# Altium schematic sheet Control.SchDoc(Control)
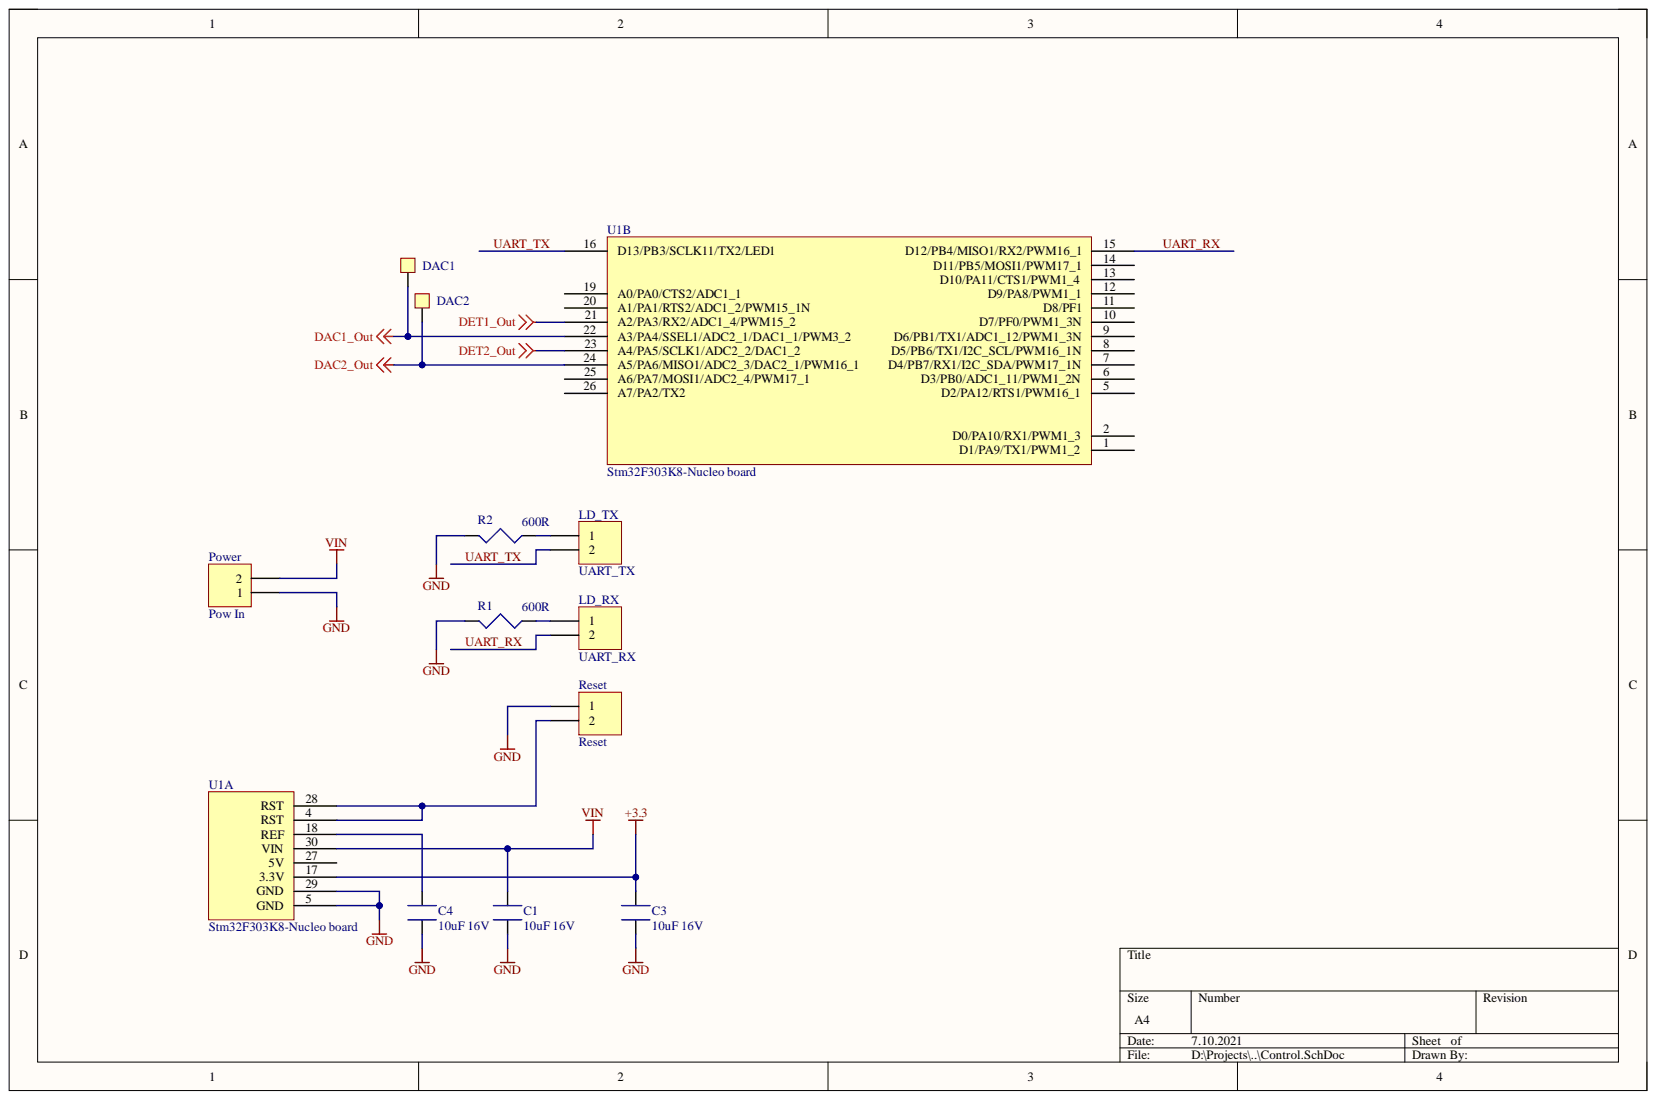
[diagram p0: Control.SchDoc]

## Parts
C1  10uF 16V  [0805_C]
C3  10uF 16V  [0805_C]
C4  10uF 16V  [0805_C]
DAC1  Point  [Test_Point]  (value not drawn)
DAC2  Point  [Test_Point]  (value not drawn)
LD_RX  UART_RX  [HDR1X2]
LD_TX  UART_TX  [HDR1X2]
Power  Pow In  [HDR1X2]
R1  600R  [0805_R]
R2  600R  [0805_R]
Reset  Reset  [HDR1X2]
U1  Stm32F303K8-Nucleo board  [F303-Nucleo]  (parts A,B)

## Nets
+3.3: C3.1 U1.17(3.3V)
DAC1_Out: DAC1.1 U1.22(A3/PA4/SSEL1/ADC2_1/DAC1_1/PWM3_2)
DAC2_Out: DAC2.1 U1.24(A5/PA6/MISO1/ADC2_3/DAC2_1/PWM16_1)
DET1_Out: U1.21(A2/PA3/RX2/ADC1_4/PWM15_2)
DET2_Out: U1.23(A4/PA5/SCLK1/ADC2_2/DAC1_2)
GND: C1.2 C3.2 C4.2 Power.1 R1.1 R2.1 Reset.1 U1.5 U1.29(GND)
VIN: C1.1 Power.2 U1.30(VIN)
UART_RX: LD_RX.2 U1.15(D12/PB4/MISO1/RX2/PWM16_1)
UART_TX: LD_TX.2 U1.16(D13/PB3/SCLK11/TX2/LED1)
NetC4_1: C4.1 U1.18(REF)
NetLD_RX_1: LD_RX.1 R1.2
NetLD_TX_1: LD_TX.1 R2.2
NetReset_2: Reset.2 U1.4(RST) U1.28(RST)

Not connected: U1 1(D1/PA9/TX1/PWM1_2) 2(D0/PA10/RX1/PWM1_3) 6(D3/PB0/ADC1_11/PWM1_2N) 7(D4/PB7/RX1/I2C_SDA/PWM17_1N) 8(D5/PB6/TX1/I2C_SCL/PWM16_1N) 9(D6/PB1/TX1/ADC1_12/PWM1_3N) 10(D7/PF0/PWM1_3N) 11(D8/PF1) 12(D9/PA8/PWM1_1) 13(D10/PA11/CTS1/PWM1_4) 14(D11/PB5/MOSI1/PWM17_1) 19(A0/PA0/CTS2/ADC1_1) 20(A1/PA1/RTS2/ADC1_2/PWM15_1N) 25(A6/PA7/MOSI1/ADC2_4/PWM17_1) 26(A7/PA2/TX2) 27(5V)

## Library data of the parts
C1 C3 C4 = Cap: Component Kind: Standard; Library Name: Schlib1.SchLib
LD_RX LD_TX Power Reset = Header 2: Header, 2-Pin; Component Kind: Standard; LatestRevisionDate: 17-Jul-2002; LatestRevisionNote: Re-released for DXP Platform.; Library Name: Miscellaneous Connectors.IntLib; Publisher: Altium Limited
R1 R2 = Res: Component Kind: Standard; Library Name: Schlib1.SchLib
U1 = F303-Nucleo: Component Kind: Standard; Library Name: Schlib1.SchLib
DAC1 DAC2 = Point: Component Kind: Standard; Library Name: Schlib1.SchLib
